# Altium schematic sheet cree_lamp.SchDoc
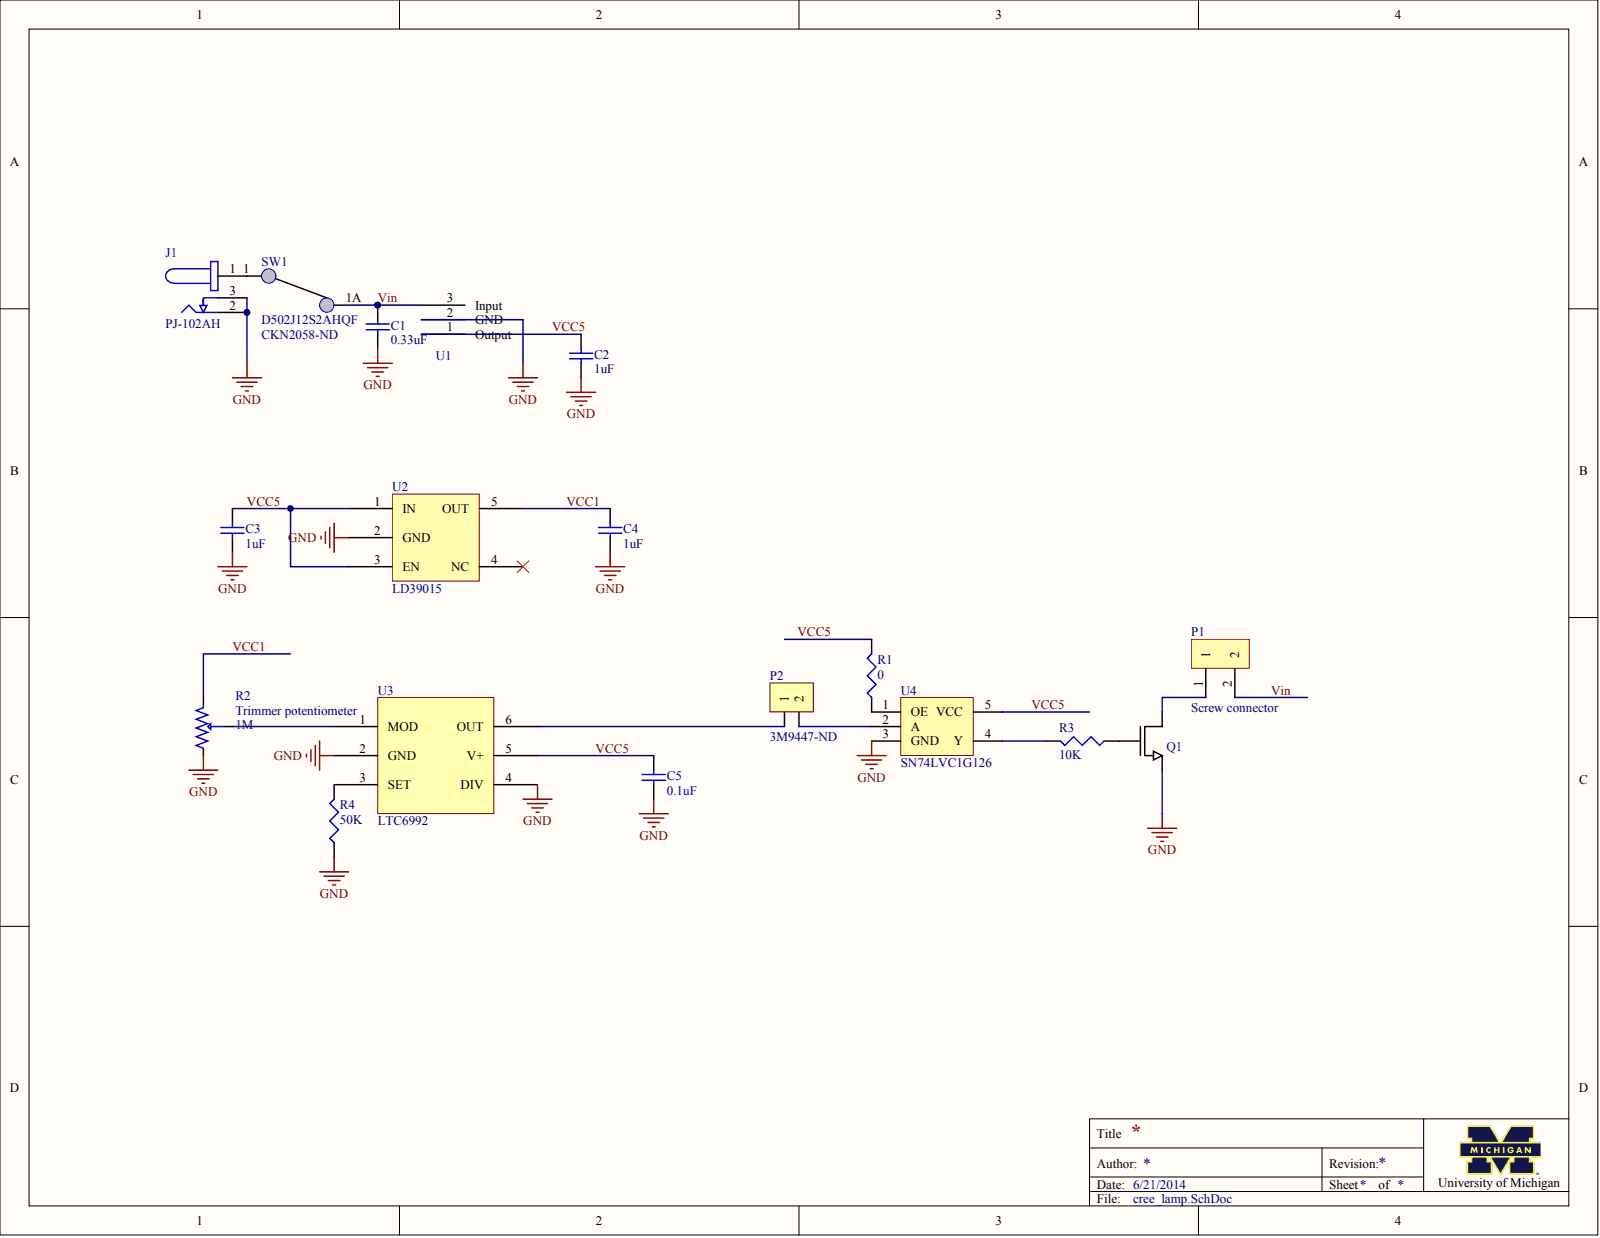
[diagram p0: cree_lamp.SchDoc]

## Parts
C1  value 0.33uF  (hidden comment: Cap Semi)
C2  value 1uF  (hidden comment: Cap Semi)
C3  value 1uF  (hidden comment: Cap Semi)
C4  value 1uF  (hidden comment: Cap Semi)
C5  value 0.1uF  (hidden comment: Cap Semi)
J1  PJ-102AH
P1  Screw connector
P2  Supplier Part Number 1: 3M9447-ND  (hidden comment: 1 x 2 header)
Q1  Si2312CDS  (value not drawn)
R1  value 0  (hidden comment: Res3)
R2  Trimmer potentiometer  value 1M
R3  value 10K  (hidden comment: Res3)
R4  value 50K  (hidden comment: Res3)
SW1  D502J12S2AHQF  Supplier Part Number 1: CKN2058-ND
U1  LM78L05  (value not drawn)
U2  LD39015
U3  LTC6992
U4  SN74LVC1G126

## Nets
GND: C1.1 C2.1 C3.1 C4.1 C5.1 J1.2 J1.3 Q1.2 R2.1 R4.1 U1.2 U2.2(GND) U3.2(GND) U3.4(DIV) U4.3(GND)
VCC1: C4.2 R2.3 U2.5(OUT)
VCC5: C2.2 C3.2 C5.2 R1.2 U1.1 U2.1(IN) U2.3(EN) U3.5(V+) U4.5(VCC)
VIN: C1.2 P1.2 SW1.1A U1.3
NetJ1_1: J1.1 SW1.1
NetP1_1: P1.1 Q1.3
NetP2_1: P2.1 U3.6(OUT)
NetP2_2: P2.2 U4.2(A)
NetQ1_1: Q1.1 R3.1
NetR1_1: R1.1 U4.1(OE)
NetR2_2: R2.2 U3.1(MOD)
NetR3_2: R3.2 U4.4(Y)
NetR4_2: R4.2 U3.3(SET)

Not connected: U2 4(NC)

## Library data of the parts
C1-C5 J1 P1 P2 Q1 R1-R4 SW1 U1-U4 = (no symbol name)
  C1-C5: Published: 8-Jun-2000; LatestRevisionDate: 29-May-2009; LatestRevisionNote: IPC-7351 Footprint Added.; PackageReference: C1206; PackageDescription: Chip Capacitor
  J1: Supplier 1: Digi-Key; Supplier Part Number 1: CP-102AH-ND
  P1: Supplier 1: Digi-Key; Supplier Part Number 1: 277-1273-ND
  P2 SW1: Supplier 1: Digi-Key
  Q1: Supplier 1: Digi-Key; Supplier Part Number 1: SI2312CDS-T1-GE3DKR-ND
  R1 R3 R4: Published: 8-Jun-2000; LatestRevisionDate: 29-May-2009; LatestRevisionNote: IPC-7351 Footprint Added.; PackageReference: J1-0603; PackageDescription: Chip Resistor
  R2: Supplier 1: Digi-Key; Supplier Part Number 1: 3386P-1-105TLF-ND; Supplier 2: Digi-Key; Supplier Part Number 2: 3296Y-1-505LF-ND
  U1: Supplier 1: Digi-Key; Supplier Part Number 1: LM78L05ACZNS/NOPB-ND
  U2: Supplier 1: Digi-Key; Supplier Part Number 1: 497-6871-1-ND
  U3: Supplier 1: Digi-Key; Supplier Part Number 1: LTC6992CS6-1#TRMPBFTR-ND
  U4: Supplier 1: Digi-Key; Supplier Part Number 1: 296-11605-1-ND
  C1-C5 R1 R3 R4: Publisher: Altium Limited
